# Altium schematic sheet blip_sheet1.SchDoc
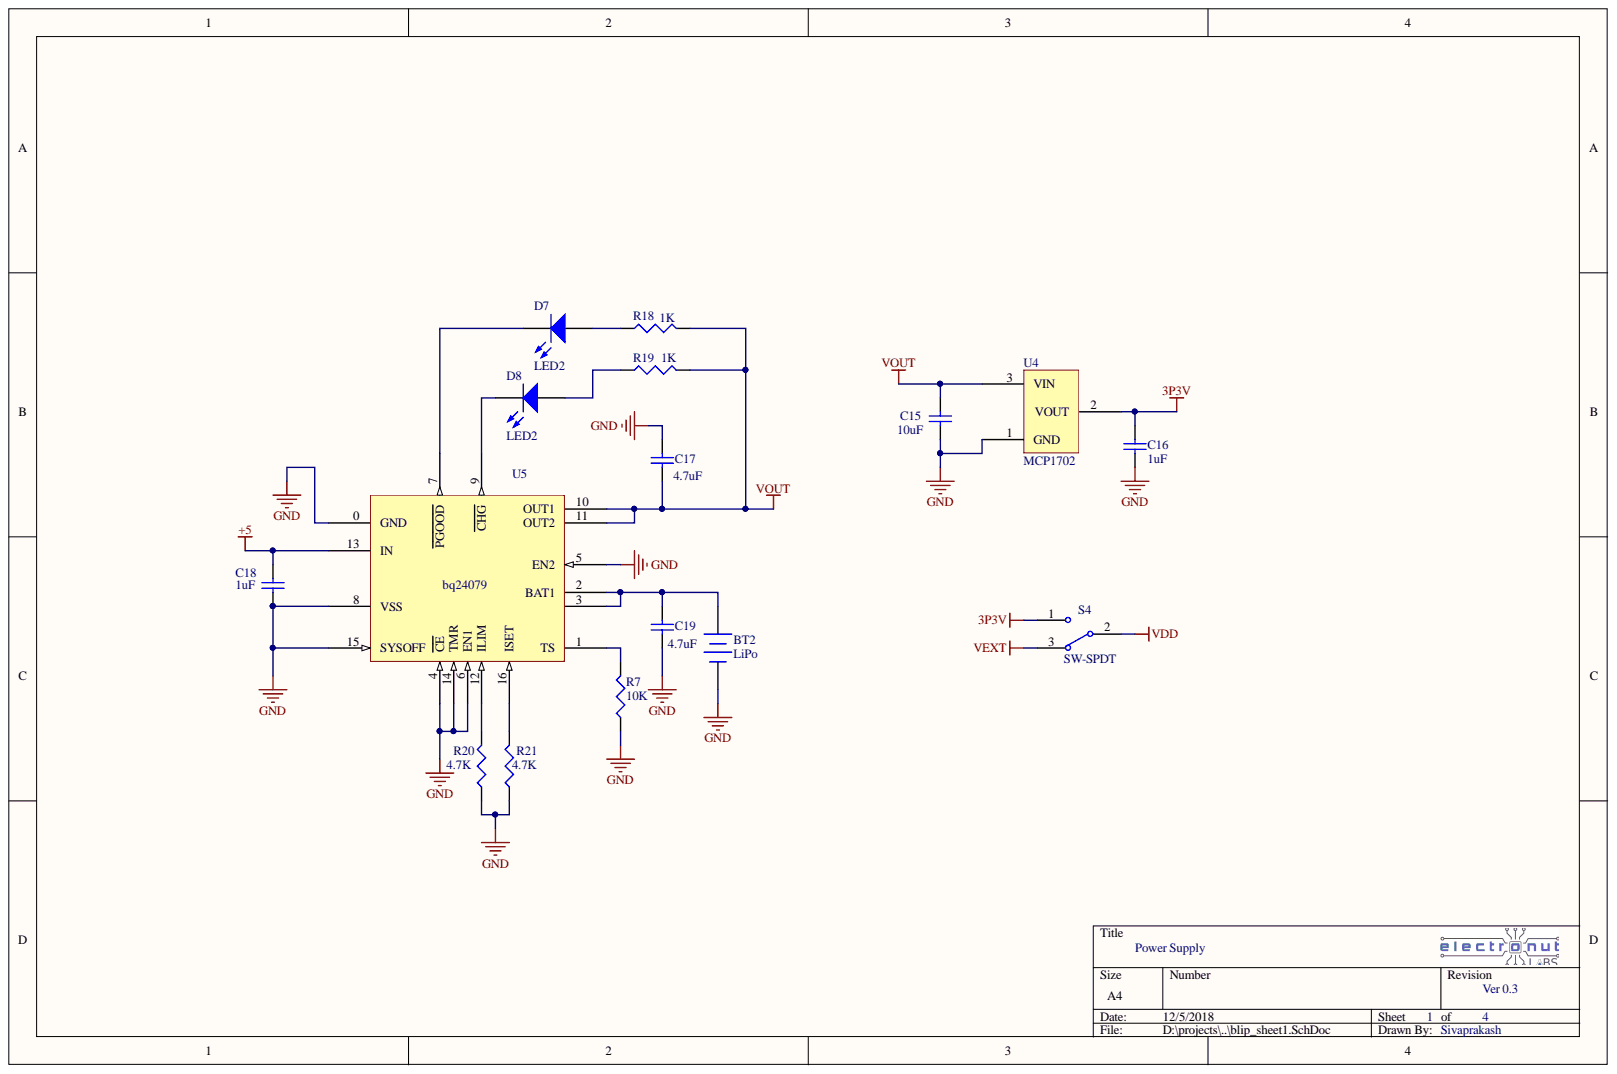
[diagram p0: blip_sheet1.SchDoc]

## Parts
BT2  LiPo  [2PIN_JST]
C15  10uF  [1608[0603]]
C16  1uF  [1608[0603]]
C17  4.7uF  [1608[0603]]
C18  1uF  [1608[0603]]
C19  4.7uF  [1608[0603]]
D7  LED2  [1608[0603]]
D8  LED2  [1608[0603]]
R7  10K  [J1-0603]
R18  1K  [J1-0603]
R19  1K  [J1-0603]
R20  4.7K  [J1-0603]
R21  4.7K  [J1-0603]
S4  SW-SPDT  [Slide_Switch_SPDT]
U4  MCP1702  [SOT-23_N]
U5  bq24079  [16-VQFN 0.5mm Pitch]

## Nets
3P3V: C16.2 S4.1 U4.2
+5: C18.2 U5.13(IN)
GND: BT2.2 C15.1 C16.1 C17.2 C18.1 C19.1 R7.1 R20.1 R21.1 U4.1 U5.0(GND) U5.4(CE) U5.5(EN2) U5.6(EN1) U5.8(VSS) U5.14(TMR) U5.15(SYSOFF)
VDD: S4.2
VEXT: S4.3
VOUT: C15.2 C17.1 R18.2 R19.2 U4.3 U5.10(OUT1) U5.11(OUT2)
NetBT2_1: BT2.1 C19.2 U5.2(BAT1) U5.3
NetD7_1: D7.1 R18.1
NetD7_2: D7.2 U5.7(PGOOD)
NetD8_1: D8.1 R19.1
NetD8_2: D8.2 U5.9(CHG)
NetR7_2: R7.2 U5.1(TS)
NetR20_2: R20.2 U5.12(ILIM)
NetR21_2: R21.2 U5.16(ISET)

## Library data of the parts
BT2 = Battery: Multicell Battery; Component Kind: Standard; LatestRevisionDate: 17-Jul-2002; LatestRevisionNote: Re-released for DXP Platform.; Library Name: Miscellaneous Devices.IntLib; PackageDescription: Battery; 2 Leads; PackageReference: BAT-2; Published: 24-Mar-1999; Publisher: Altium Limited
C15-C19 = Cap Semi: Capacitor (Semiconductor SIM Model); Component Kind: Standard; LatestRevisionDate: 29-May-2009; LatestRevisionNote: IPC-7351 Footprint Added.; Library Name: Miscellaneous Devices.IntLib; PackageDescription: Chip Capacitor; PackageReference: C1206; Published: 8-Jun-2000; Signal Integrity: Cap Semi; Simulation: CAP; Publisher: Altium Limited
D7 D8 = LED2: Typical RED, GREEN, YELLOW, AMBER GaAs LED; Component Kind: Standard; LatestRevisionDate: 17-Jul-2002; LatestRevisionNote: Re-released for DXP Platform.; Library Name: Miscellaneous Devices.IntLib; PackageDescription: SM LED; 2 Flat Leads; PackageReference: 3.2X1.6X1.1; PackageVersion: Feb-2002; Published: 8-Jun-2000; Simulation: LED2; Publisher: Altium Limited
R7 R18-R21 = Res3: Resistor; Component Kind: Standard; LatestRevisionDate: 29-May-2009; LatestRevisionNote: IPC-7351 Footprint Added.; Library Name: Miscellaneous Devices.IntLib; PackageDescription: Chip Resistor; PackageReference: J1-0603; Published: 8-Jun-2000; Signal Integrity: Res; Simulation: RESISTOR; Publisher: Altium Limited
S4 = SW-SPDT: SPDT Subminiature Toggle Switch, Right Angle Mounting, Vertical Actuation; Component Kind: Standard; ComponentLink1Description: Manufacturer Link; ComponentLink1URL: http://www.apem.com; DatasheetVersion: 05-Dec-2000; LatestRevisionDate: 17-Dec-2003; LatestRevisionNote: Schematic revised, PCB Footprint replaced.; Library Name: Miscellaneous Devices.IntLib; PackageDescription: Switch; 4 Leads; PackageReference: TL36WW15050; Published: 24-Mar-1999; Publisher: Altium Limited
U4 = MCP1702: 3.3 V Low Quasient Current LDO; Component Kind: Standard; Library Name: blip.SchLib
U5 = bq24079: Lipo Charger; Component Kind: Standard; Library Name: blip.SchLib
